# Altium schematic sheet RS485.SchDoc(RS485)
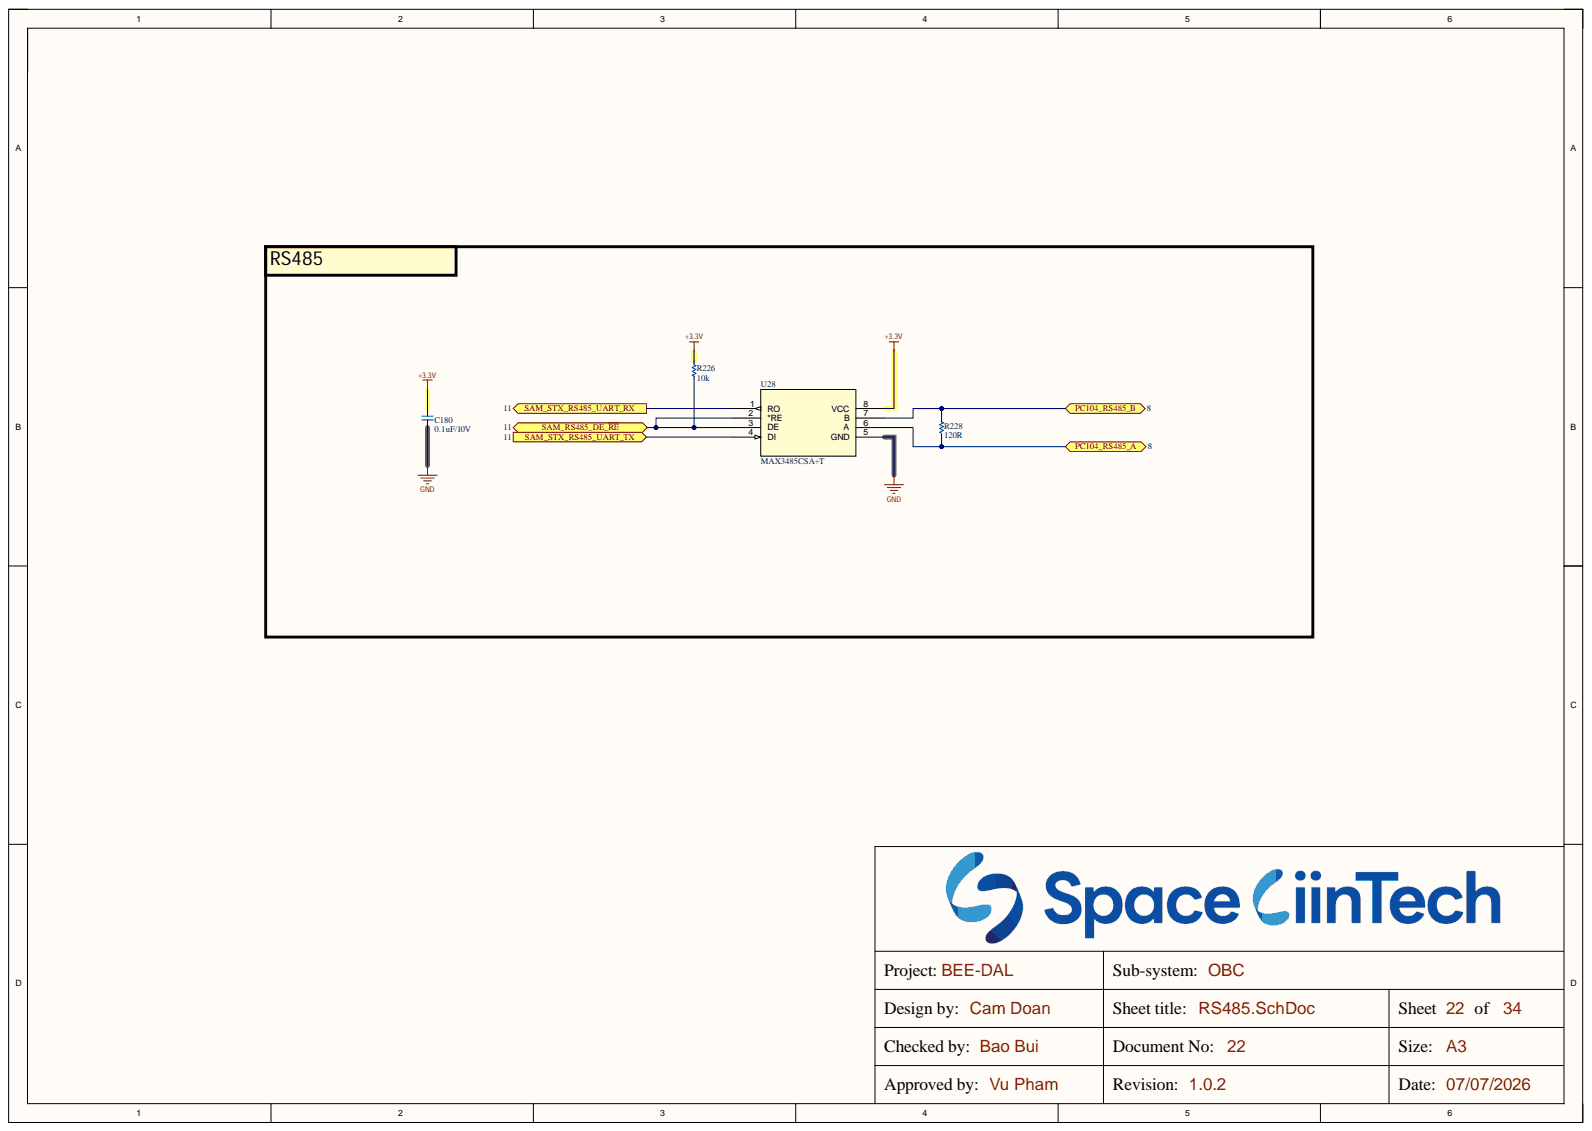
[diagram p0: RS485.SchDoc]

## Parts
C180  0.1uF/10V  [CAP_C_1005X50_L]
R226  10k  [RES_C_1005x30_L]
R228  120R  [RES_C_1005x30_L]
U28  MAX3485CSA+T  [21-0041-3-M_90-0096-8L_MXM]

## Ports
PC104_RS485_A, PC104_RS485_B, SAM_RS485_DE_~{RE}, SAM_STX_RS485_UART_RX, SAM_STX_RS485_UART_TX

## Nets
+3.3V: C180.2 R226.1 U28.8(VCC)
GND: C180.1 U28.5(GND)
PC104_RS485_A: R228.2 U28.6(A) ; port PC104_RS485_A
PC104_RS485_B: R228.1 U28.7(B) ; port PC104_RS485_B
SAM_RS485_DE_~{RE}: R226.2 U28.2(*RE) U28.3(DE) ; port SAM_RS485_DE_~{RE}
SAM_STX_RS485_UART_RX: U28.1(RO) ; port SAM_STX_RS485_UART_RX
SAM_STX_RS485_UART_TX: U28.4(DI) ; port SAM_STX_RS485_UART_TX

## Library data of the parts
C180 = _CAP_C_2: CAP; Component Kind: Standard; Library Name: LABLIB.SchLib
R226 R228 = _RES_B_2: RES; Component Kind: Standard
  R226: Library Name: LABLIB.SchLib
  R228: Library Name: IFBschelib.SchLib
U28 = MAX3485CSA+T: Low-Power, Slew-Rate-Limited RS-485/RS-422 Transceivers, IC TRANSCEIVER HALF 1/1 8SOIC; Manufacturer_Name: Analog Devices Inc; Manufacturer_Part_Number: MAX485CSA+T; Component Kind: Standard; RefDes: RefDes; Type: Type; Copyright: Copyright (C) 2025 Ultra Librarian. All rights reserved.; Library Name: IFBschelib.SchLib
